# Altium schematic sheet 2008_SablierElectronique_Alim.SchDoc
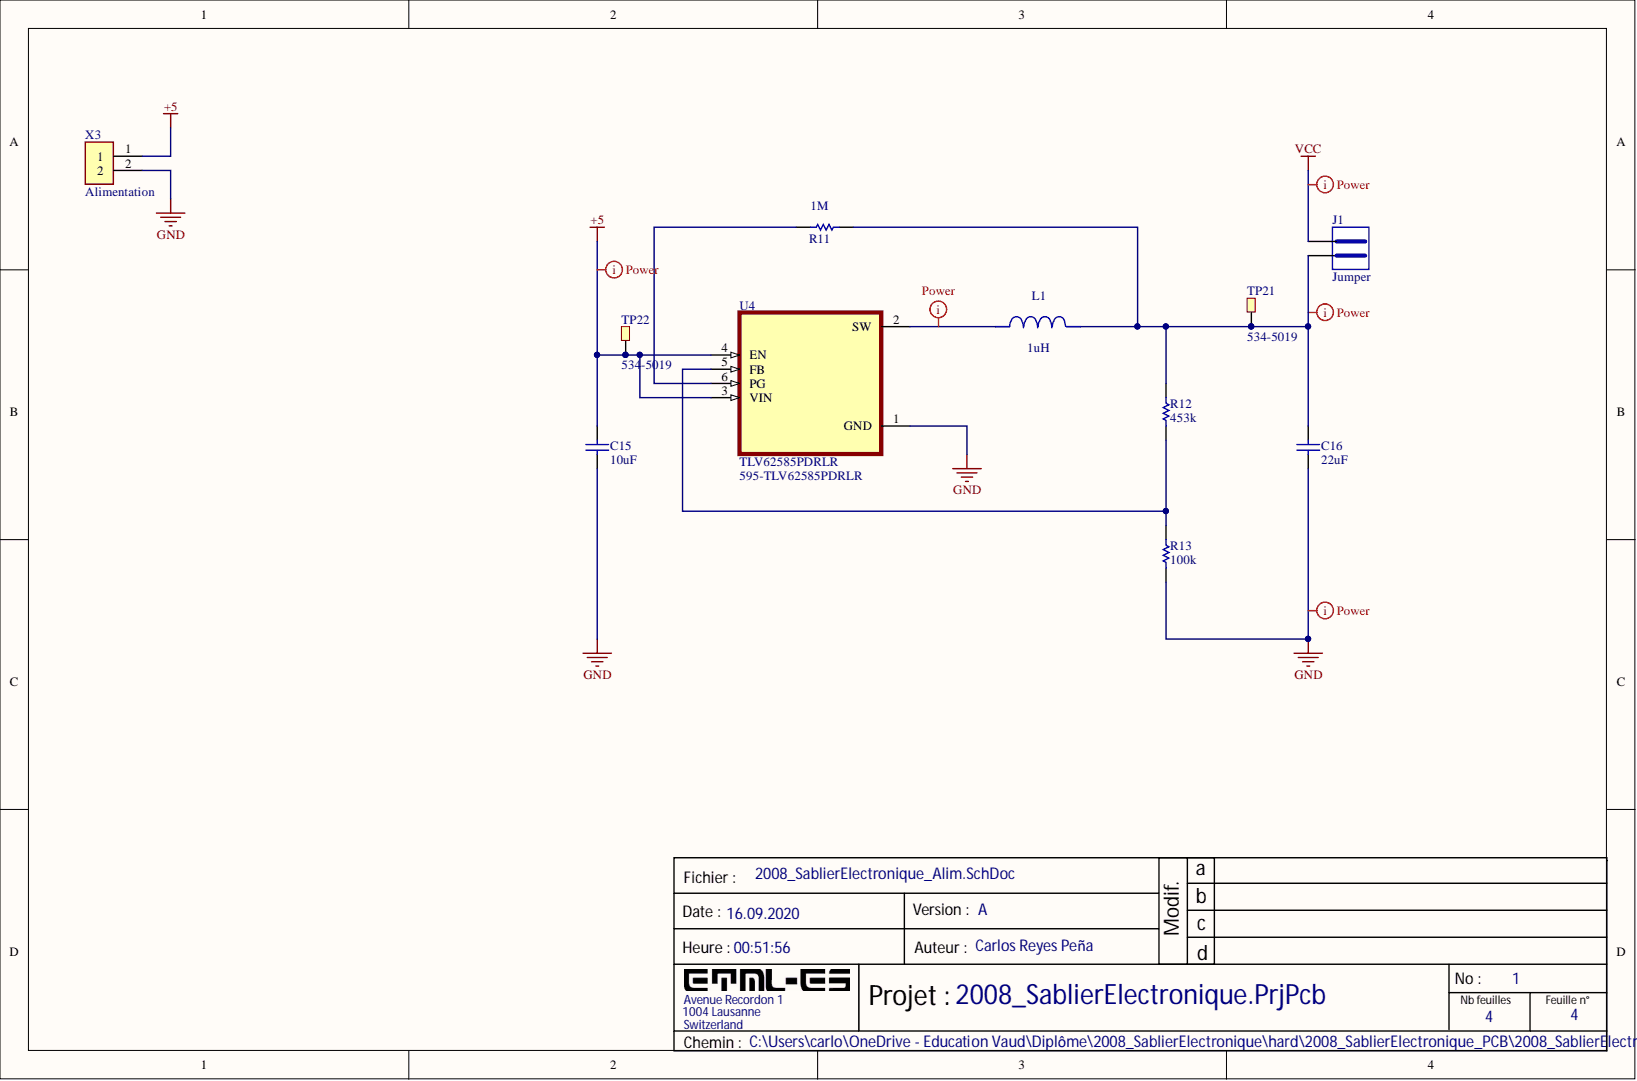
[diagram p0: 2008_SablierElectronique_Alim.SchDoc]

## Parts
C15  10uF  [RESC0805(2012)_L]
C16  22uF  [RESC0805(2012)_L]
J1  Jumper  [Jumper]
L1  value 1uH  [RESC0805(2012)_L]  (hidden comment: LQM21PN1R0MCHD0)
R11  1M  [RESC0805(2012)_L]
R12  453k  [RESC0805(2012)_L]
R13  100k  [RESC0805(2012)_L]
TP21  5019  Supplier Part Number 1: 534-5019  [TESTPOINT_SMD]
TP22  5019  Supplier Part Number 1: 534-5019  [TESTPOINT_SMD]
U4  TLV62585PDRLR  Supplier Part Number 1: 595-TLV62585PDRLR  [SOT563]
X3  Alimentation  [Header 2 pin]

## Nets
+5: C15.2 TP22.1 U4.3(VIN) U4.4(EN) X3.1
GND: C15.1 C16.1 R13.2 U4.1(GND) X3.2
VCC: J1.1
NetC16_2: C16.2 J1.2 L1.1 R11.1 R12.1 TP21.1
NetL1_2: L1.2 U4.2(SW)
NetR11_2: R11.2 U4.6(PG)
NetR12_2: R12.2 R13.1 U4.5(FB)

## Library data of the parts
C15 C16 = CAP1206: Component Kind: Standard; Library Name: 2008_SablierElectronique_SCHLIB.SchLib
J1 = Jumper_2p: Component Kind: Standard; Library Name: 2008_SablierElectronique_SCHLIB.SchLib
L1 = 744311100: LQM21PN1R0MCHD; Component Kind: Standard; Library Name: TI WEBENCH; Manufacturer Part Number: LQM21PN1R0MCHD; PackageReference: LQM21PN1R0MCHD; Supplier 1: Mouser; Supplier Part Number 1: 81-LQM21PN1R0MCHD
R11-R13 = RES: Component Kind: Standard; Library Name: 2008_SablierElectronique_SCHLIB.SchLib
TP21 TP22 = TESTPOINT: Test point smd; Component Kind: Standard; Supplier 1: Mouser; Library Name: 2008_SablierElectronique_SCHLIB.SchLib
U4 = TLV62585PDRLR: 2.5V-5.5V input, 3-A high efficiency step-down converter in 2x2 QFN or SOT563 package 6-SOT-5X3 -40 to 125; MF: Texas Instruments; Arrow_Electronics-Purchase-URL: https://snapeda.com/shop?store=Arrow+Electronics&id=3229753; Availability: Good; Component Kind: Standard; Mouser-Purchase-URL: https://snapeda.com/shop?store=Mouser&id=3229753; MP: TLV62585PDRLR; Package: SOT-5X3-6 Texas Instruments; Price: None; SnapEDA_Link: https://www.snapeda.com/parts/TLV62585PDRLR/Texas%20Instruments/view-part/3229753/?ref=snap; Supplier 1: Mouser; Texas_Instruments-Purchase-URL: https://snapeda.com/shop?store=Texas+Instruments&id=3229753; Library Name: 2008_SablierElectronique_SCHLIB.SchLib
X3 = Header 2 pin: Connector Header Through Hole 2 position; MF: TE Connectivity; MP: 826632-1; Availability: Unavailable; Component Kind: Standard; DigiKey-Purchase-URL: https://snapeda.com/shop?store=DigiKey&id=2390280; EU_RoHS_Compliance: Compliant; Mouser-Purchase-URL: https://snapeda.com/shop?store=Mouser&id=2390280; Package: None; Price: None; SnapEDA_Link: https://www.snapeda.com/parts/826632-1/TE%20Connectivity%20AMP%20Connectors/view-part/2390280/?ref=snap; Library Name: 2008_SablierElectronique_SCHLIB.SchLib
